# Altium schematic sheet mainborad.SchDoc
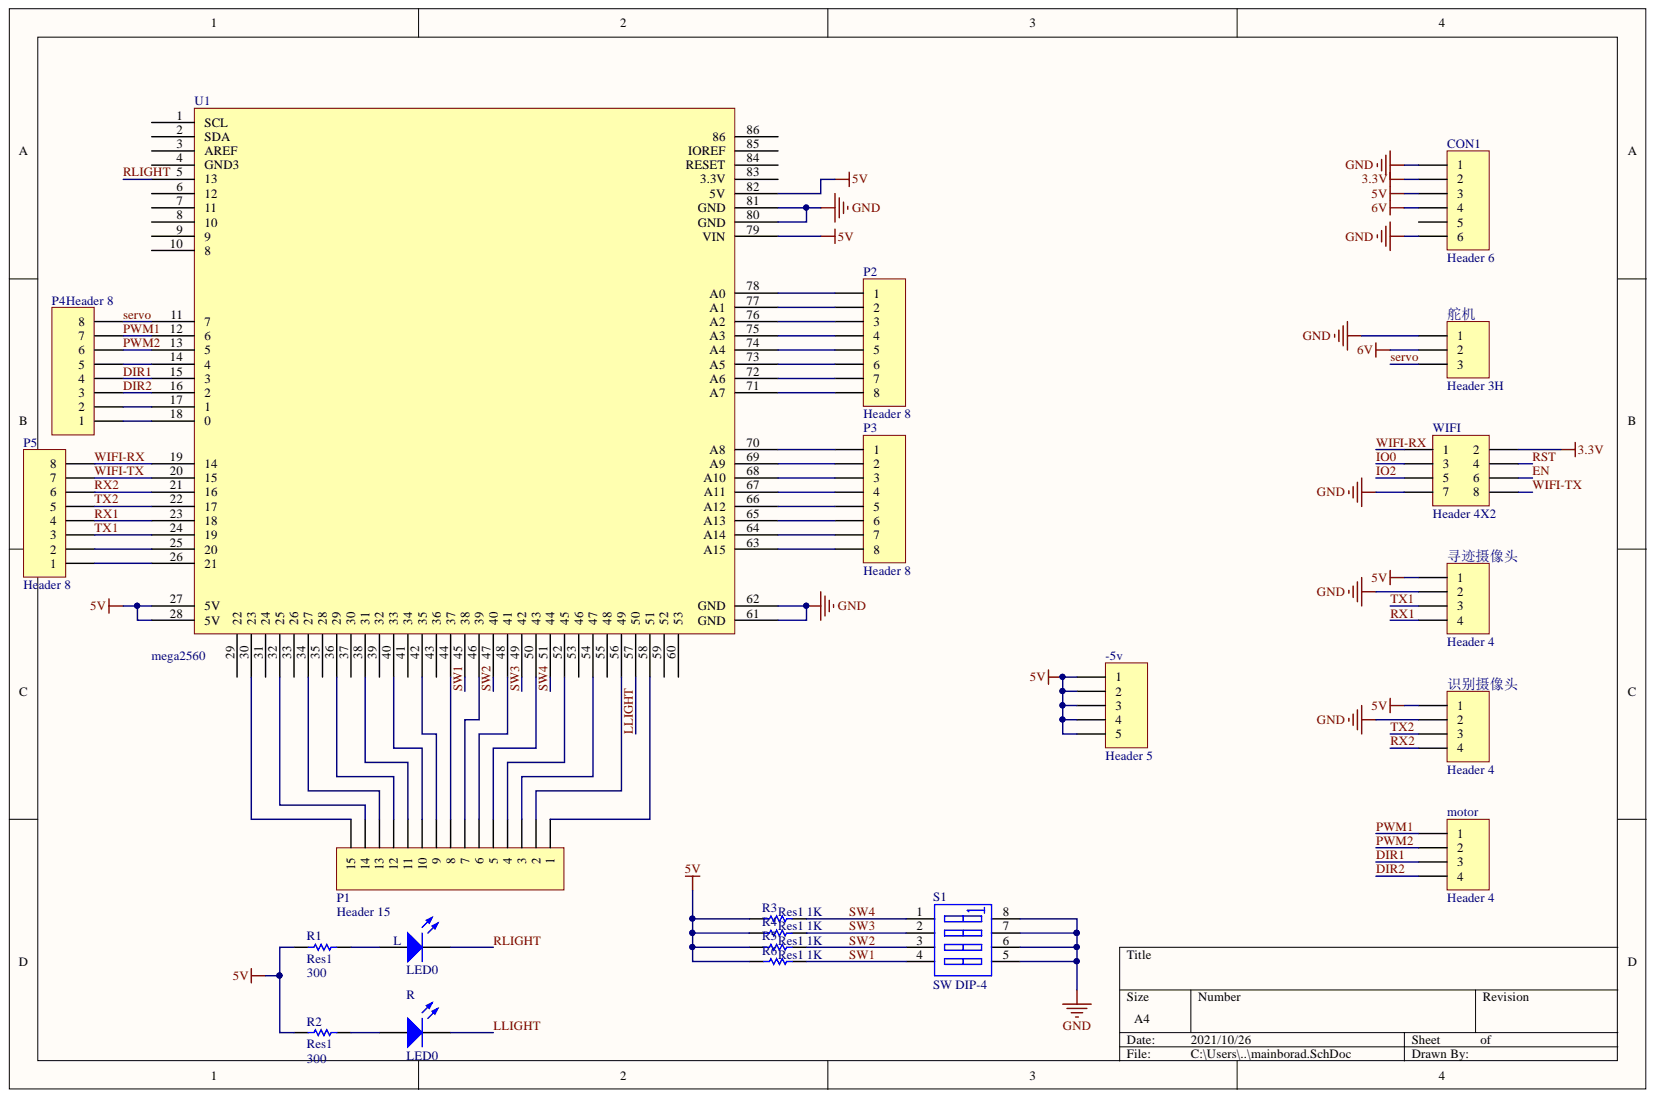
[diagram p0: mainborad.SchDoc]

## Parts
-5v  Header 5  [HDR1X5]
CON1  Header 6  [HDR1X6]
L  LED0  [LED - duplicate]
motor  Header 4  [HDR1X4]
P1  Header 15  [HDR1X15]
P2  Header 8  [HDR1X8]
P3  Header 8  [HDR1X8]
P4  Header 8  [HDR1X8]
P5  Header 8  [HDR1X8]
R  LED0  [LED - duplicate]
R1  Res1  value 300  [0805]
R2  Res1  value 300  [0805]
R3  Res1  value 1K  [0805]
R4  Res1  value 1K  [0805]
R5  Res1  value 1K  [0805]
R6  Res1  value 1K  [0805]
S1  SW DIP-4  [BM]
U1  mega2560  [mega2560]
WIFI  Header 4X2  [HDR2X4]
寻迹摄像头  Header 4  [HDR1X4]
舵机  Header 3H  [HDR1X3]
识别摄像头  Header 4  [HDR1X4]

## Nets
3.3V: CON1.2 WIFI.2
5V: -5v.1 -5v.2 -5v.3 -5v.4 -5v.5 CON1.3 R1.1 R2.1 R3.1 R4.1 R5.1 R6.1 U1.27(5V) U1.28(5V) U1.79(VIN) U1.82(5V) 寻迹摄像头.1 识别摄像头.1
6V: CON1.4 舵机.2
GND: CON1.1 CON1.6 S1.5 S1.6 S1.7 S1.8 U1.61(GND) U1.62(GND) U1.80(GND) U1.81(GND) WIFI.7 寻迹摄像头.2 舵机.1 识别摄像头.2
DIR1: motor.3 P4.4 U1.15
DIR2: motor.4 P4.3 U1.16
EN: WIFI.6
IO0: WIFI.3
IO2: WIFI.5
LLIGHT: R.2 U1.57
PWM1: motor.1 P4.7 U1.12
PWM2: motor.2 P4.6 U1.13
RLIGHT: L.2 U1.5
RST: WIFI.4
RX1: P5.4 U1.23 寻迹摄像头.4
RX2: P5.6 U1.21 识别摄像头.4
servo: P4.8 U1.11 舵机.3
SW1: R6.2 S1.4 U1.45
SW2: R5.2 S1.3 U1.47
SW3: R4.2 S1.2 U1.49
SW4: R3.2 S1.1 U1.51
TX1: P5.3 U1.24 寻迹摄像头.3
TX2: P5.5 U1.22 识别摄像头.3
WIFI-RX: P5.8 U1.19 WIFI.1
WIFI-TX: P5.7 U1.20 WIFI.8
NetL_1: L.1 R1.2
NetP1_1: P1.1 U1.58
NetP1_2: P1.2 U1.56
NetP1_3: P1.3 U1.54
NetP1_4: P1.4 U1.52
NetP1_5: P1.5 U1.50
NetP1_6: P1.6 U1.48
NetP1_7: P1.7 U1.46
NetP1_8: P1.8 U1.44
NetP1_9: P1.9 U1.42
NetP1_10: P1.10 U1.40
NetP1_11: P1.11 U1.38
NetP1_12: P1.12 U1.36
NetP1_13: P1.13 U1.34
NetP1_14: P1.14 U1.32
NetP1_15: P1.15 U1.30
NetP2_1: P2.1 U1.78(A0)
NetP2_2: P2.2 U1.77(A1)
NetP2_3: P2.3 U1.76(A2)
NetP2_4: P2.4 U1.75(A3)
NetP2_5: P2.5 U1.74(A4)
NetP2_6: P2.6 U1.73(A5)
NetP2_7: P2.7 U1.72(A6)
NetP2_8: P2.8 U1.71(A7)
NetP3_1: P3.1 U1.70(A8)
NetP3_2: P3.2 U1.69(A9)
NetP3_3: P3.3 U1.68(A10)
NetP3_4: P3.4 U1.67(A11)
NetP3_5: P3.5 U1.66(A12)
NetP3_6: P3.6 U1.65(A13)
NetP3_7: P3.7 U1.64(A14)
NetP3_8: P3.8 U1.63(A15)
NetP4_1: P4.1 U1.18
NetP4_2: P4.2 U1.17
NetP4_5: P4.5 U1.14
NetP5_1: P5.1 U1.26
NetP5_2: P5.2 U1.25
NetR2_2: R.1 R2.2

Not connected: CON1 5; U1 1(SCL) 2(SDA) 3(AREF) 4(GND3) 6 7 8 9 10 29 31 33 35 37 39 41 43 53 55 59 60 83(3.3V) 84(RESET) 85(IOREF) 86

## Library data of the parts
-5v = Header 5: Header, 5-Pin; Component Kind: Standard; LatestRevisionDate: 17-Jul-2002; LatestRevisionNote: Re-released for DXP Platform.; Library Name: Miscellaneous Connectors.IntLib; Signal Integrity: Connector; Publisher: Altium Limited
CON1 = MHDR1X6: Header, 6-Pin; Component Kind: Standard; LatestRevisionDate: 17-Jul-2002; LatestRevisionNote: Re-released for DXP Platform.; Library Name: Miscellaneous Connectors.IntLib; Signal Integrity: Connector; Publisher: Altium Limited
L R = LED0: Typical INFRARED GaAs LED; Component Kind: Standard; LatestRevisionDate: 08-Jul-2005; LatestRevisionNote: Mech Layer 1 removed.; Library Name: Miscellaneous Devices.IntLib; PackageDescription: LED; 2 Leads; PackageReference: LED-0; Published: 8-Jun-2000; Simulation: LED0; Publisher: Altium Limited
motor 寻迹摄像头 识别摄像头 = Header 4: Header, 4-Pin; Component Kind: Standard; LatestRevisionDate: 17-Jul-2002; LatestRevisionNote: Re-released for DXP Platform.; Library Name: Miscellaneous Connectors.IntLib; Signal Integrity: Connector; Publisher: Altium Limited
P1 = Header 15: Header, 15-Pin; Component Kind: Standard; LatestRevisionDate: 17-Jul-2002; LatestRevisionNote: Re-released for DXP Platform.; Library Name: Miscellaneous Connectors.IntLib; Signal Integrity: Connector; Publisher: Altium Limited
P2-P5 = Header 8: Header, 8-Pin; Component Kind: Standard; LatestRevisionDate: 17-Jul-2002; LatestRevisionNote: Re-released for DXP Platform.; Library Name: Miscellaneous Connectors.IntLib; Signal Integrity: Connector; Publisher: Altium Limited
R1-R6 = Res1: Resistor; Component Kind: Standard; LatestRevisionDate: 17-Jul-2002; LatestRevisionNote: Re-released for DXP Platform.; Library Name: Miscellaneous Devices.IntLib; PackageDescription: Axial Device, Thru-Hole; 2 Leads; 0.3 in Pin Spacing; PackageReference: AXIAL-0.3; Published: 8-Jun-2000; Signal Integrity: Res; Simulation: RESISTOR; Publisher: Altium Limited
S1 = SW DIP-4: DIP Switch, 4 Position, SPST; Code_IPC: SOIC127P600-8; Code_JEDEC: MS-012-AA; Component Kind: Standard; LatestRevisionDate: 29-May-2009; LatestRevisionNote: IPC-7351 Footprint Added.; Library Name: Miscellaneous Devices.IntLib; PackageDescription: 8-Pin Small Outline Integrated Circuit 1.27 mm Pitch; PackageReference: SO8; PackageVersion: Dec-2002; Published: 24-Mar-1999; Simulation: dpsw4; Publisher: Altium Limited
WIFI = Header 4X2: Header, 4-Pin, Dual row; Component Kind: Standard; LatestRevisionDate: 17-Jul-2002; LatestRevisionNote: Re-released for DXP Platform.; Library Name: Miscellaneous Connectors.IntLib; Signal Integrity: Connector; Publisher: Altium Limited
舵机 = Header 3H: Header, 3-Pin, Right Angle; Component Kind: Standard; LatestRevisionDate: 17-Jul-2002; LatestRevisionNote: Re-released for DXP Platform.; Library Name: Miscellaneous Connectors.IntLib; Signal Integrity: Connector; Publisher: Altium Limited
U1 = mega2560: Component Kind: Standard; Library Name: mega2560.IntLib
